# Altium schematic sheet Sheet1.SchDoc
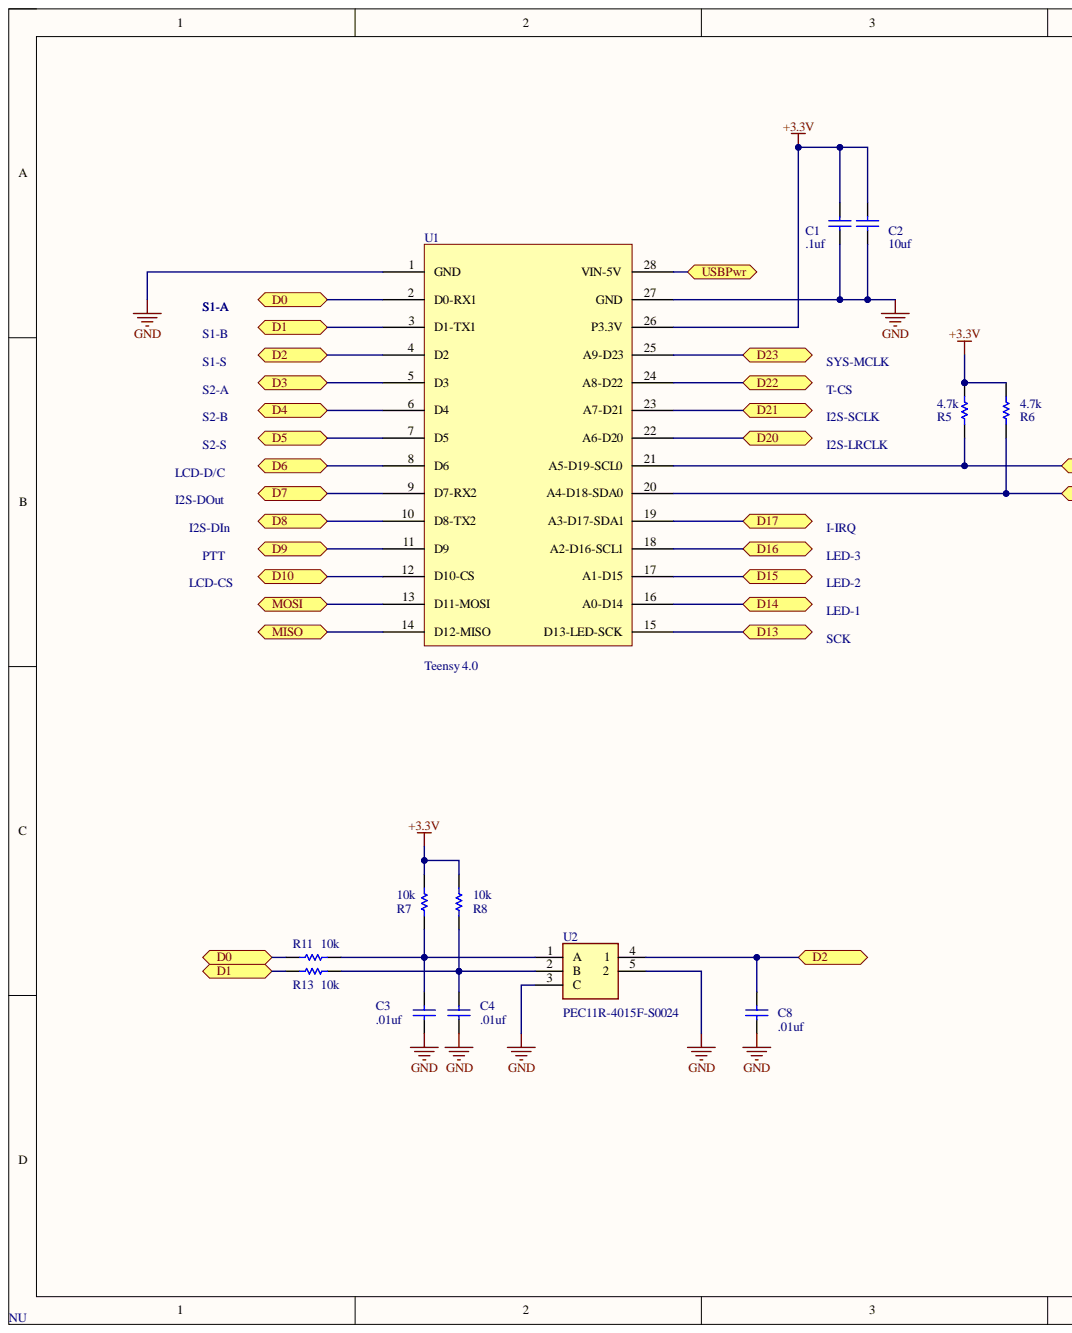
[diagram p0: Sheet1.SchDoc, left]
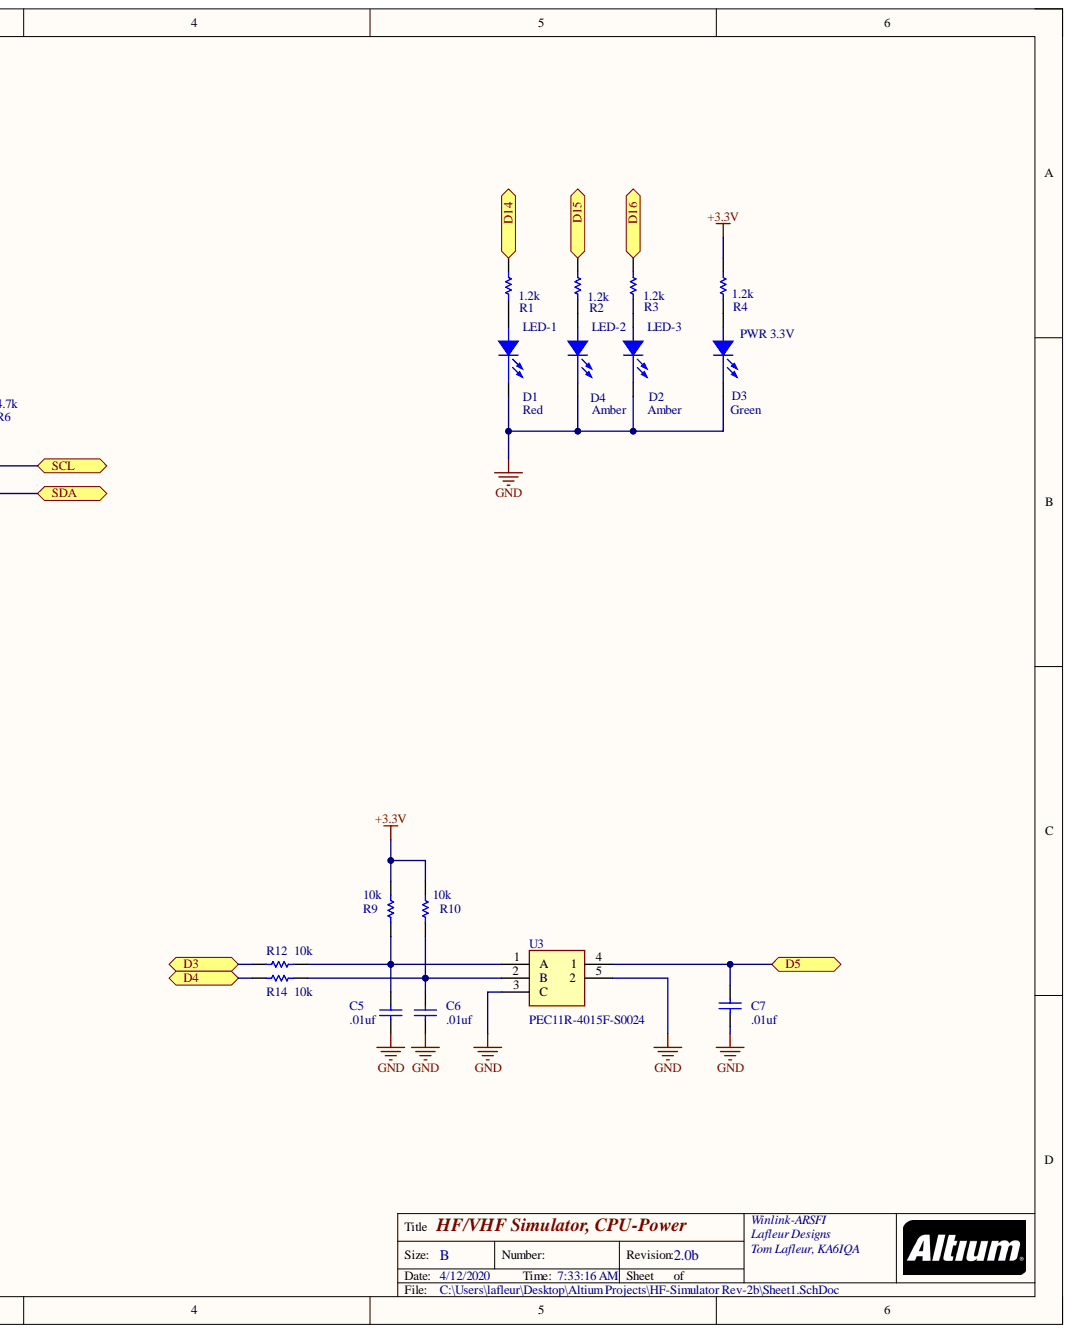
[diagram p1: Sheet1.SchDoc, right]

## Parts
C1  .1uf  [6-0805]
C2  10uf  [0805]
C3  .01uf  [6-0805]
C4  .01uf  [6-0805]
C5  .01uf  [6-0805]
C6  .01uf  [6-0805]
C7  .01uf  [6-0805]
C8  .01uf  [6-0805]
D1  LED-1  [LED 0805]
D2  LED-3  [LED 0805]
D3  PWR 3.3V  [LED 0805]
D4  LED-2  [LED 0805]
R1  1.2k  [6-0805]
R2  1.2k  [6-0805]
R3  1.2k  [6-0805]
R4  1.2k  [6-0805]
R5  4.7k  [6-0805]
R6  4.7k  [6-0805]
R7  10k  [6-0805]
R8  10k  [6-0805]
R9  10k  [6-0805]
R10  10k  [6-0805]
R11  10k  [6-0805]
R12  10k  [6-0805]
R13  10k  [6-0805]
R14  10k  [6-0805]
U1  Teensy 4.0  [DIP28-.25pins]
U2  PEC11R-4015F-S0024  [PEC12R-4XXXF-SXXXX_1]
U3  PEC11R-4015F-S0024  [PEC12R-4XXXF-SXXXX_1]

## Ports
D0, D1, D2, D3, D4, D5, D6, D7, D8, D9, D10, D13, D14, D15, D16, D17, D20, D21, D22, D23, MISO, MOSI, SCL, SDA, USBPwr

## Nets
+3.3V: C1.1 C2.1 R4.2 R5.1 R6.1 R7.1 R8.1 R9.1 R10.1 U1.26(P3.3V)
GND: C1.2 C2.2 C3.2 C4.2 C5.2 C6.2 C7.2 C8.2 D1.2 D2.2 D3.2 D4.2 U1.1(GND) U1.27(GND) U2.3(C) U2.5 U3.3(C) U3.5
D0: R11.1 U1.2(D0-RX1) ; port D0
D1: R13.1 U1.3(D1-TX1) ; port D1
D2: C8.1 U1.4(D2) U2.4 ; port D2
D3: R12.1 U1.5(D3) ; port D3
D4: R14.1 U1.6(D4) ; port D4
D5: C7.1 U1.7(D5) U3.4 ; port D5
D6: U1.8(D6) ; port D6
D7: U1.9(D7-RX2) ; port D7
D8: U1.10(D8-TX2) ; port D8
D9: U1.11(D9) ; port D9
D10: U1.12(D10-CS) ; port D10
D13: U1.15(D13-LED-SCK) ; port D13
D14: R1.2 U1.16(A0-D14) ; port D14
D15: R2.2 U1.17(A1-D15) ; port D15
D16: R3.2 U1.18(A2-D16-SCL1) ; port D16
D17: U1.19(A3-D17-SDA1) ; port D17
D20: U1.22(A6-D20) ; port D20
D21: U1.23(A7-D21) ; port D21
D22: U1.24(A8-D22) ; port D22
D23: U1.25(A9-D23) ; port D23
MISO: U1.14(D12-MISO) ; port MISO
MOSI: U1.13(D11-MOSI) ; port MOSI
SCL: R5.2 U1.21(A5-D19-SCL0) ; port SCL
SDA: R6.2 U1.20(A4-D18-SDA0) ; port SDA
USBPwr: U1.28(VIN-5V) ; port USBPwr
NetC3_1: C3.1 R7.2 R11.2 U2.1(A)
NetC4_1: C4.1 R8.2 R13.2 U2.2(B)
NetC5_1: C5.1 R9.2 R12.2 U3.1(A)
NetC6_1: C6.1 R10.2 R14.2 U3.2(B)
NetD1_1: D1.1 R1.1
NetD2_1: D2.1 R3.1
NetD3_1: D3.1 R4.1
NetD4_1: D4.1 R2.1

## Library data of the parts
C1-C8 = CAP_1: Capacitor; Fitted: True; Component Kind: Standard; Library Name: N2K Project.SCHLIB
D1-D4 = LTST150: Part Description: SMT LED Green; Vendor Part Number: LTST-C150GKRT; DEVICE: C150; Flex_PN: D20000; Vendor: LITEON; Component Kind: Standard; Library Name: Winmor-Demo-R1.IntLib
R1-R14 = ERJ8EN: Precision Thick Film Chip Resistor, 10 Ohm to 2.2M Ohm Range, 1% Tolerance, 1206 Size, 0.125 W; Code_IPC: 3216 [1206]; Component Kind: Standard; ComponentLink1Description: Manufacturer Link; ComponentLink1URL: http://www.panasonic.com/industrial/components/resistive_products.htm; ComponentLink2Description: Datasheet; ComponentLink2URL: http://www.panasonic.com/industrial/components/pdf/AOA0000CE2.pdf; LatestRevisionDate: 19-Mar-2004; LatestRevisionNote: Silkscreen size reduced.; PackageDocument: Mar-2003; PackageReference: 8-1206; Published: 17-Dec-2003; Publisher: Altium Limited
  R5-R14: Library Name: Panasonic Resistor.IntLib; Signal Integrity: Res; Simulation: RESISTOR
  R1-R4: Library Name: Winmor-Demo-R1.IntLib
U1 = Teensy  4.0: Teensy 4.0 SBC; Component Kind: Standard; Library Name: HF-Simulator Rev-1_1.SCHLIB
U2 U3 = PEC11R-4015F-S0024: Undefined or Miscellaneous; Manufacturer_Name: Bourns; Allied_Number: 70407905; Allied Price/Stock: https://www.alliedelec.com/bourns-pec11r-4015f-s0024/70407905/; Component Kind: Standard; Datasheet Link: https://www.bourns.com/docs/Product-Datasheets/pec11R.pdf; Manufacturer_Part_Number: PEC11R-4015F-S0024; Mouser Part Number: 652-PEC11R-4015F-S24; Mouser Price/Stock: https://www.mouser.com/Search/Refine.aspx?Keyword=652-PEC11R-4015F-S24; RS Part Number: 7377739P; RS Price/Stock: http://uk.rs-online.com/web/p/products/7377739P
